# Altium schematic sheet L1.SchDoc
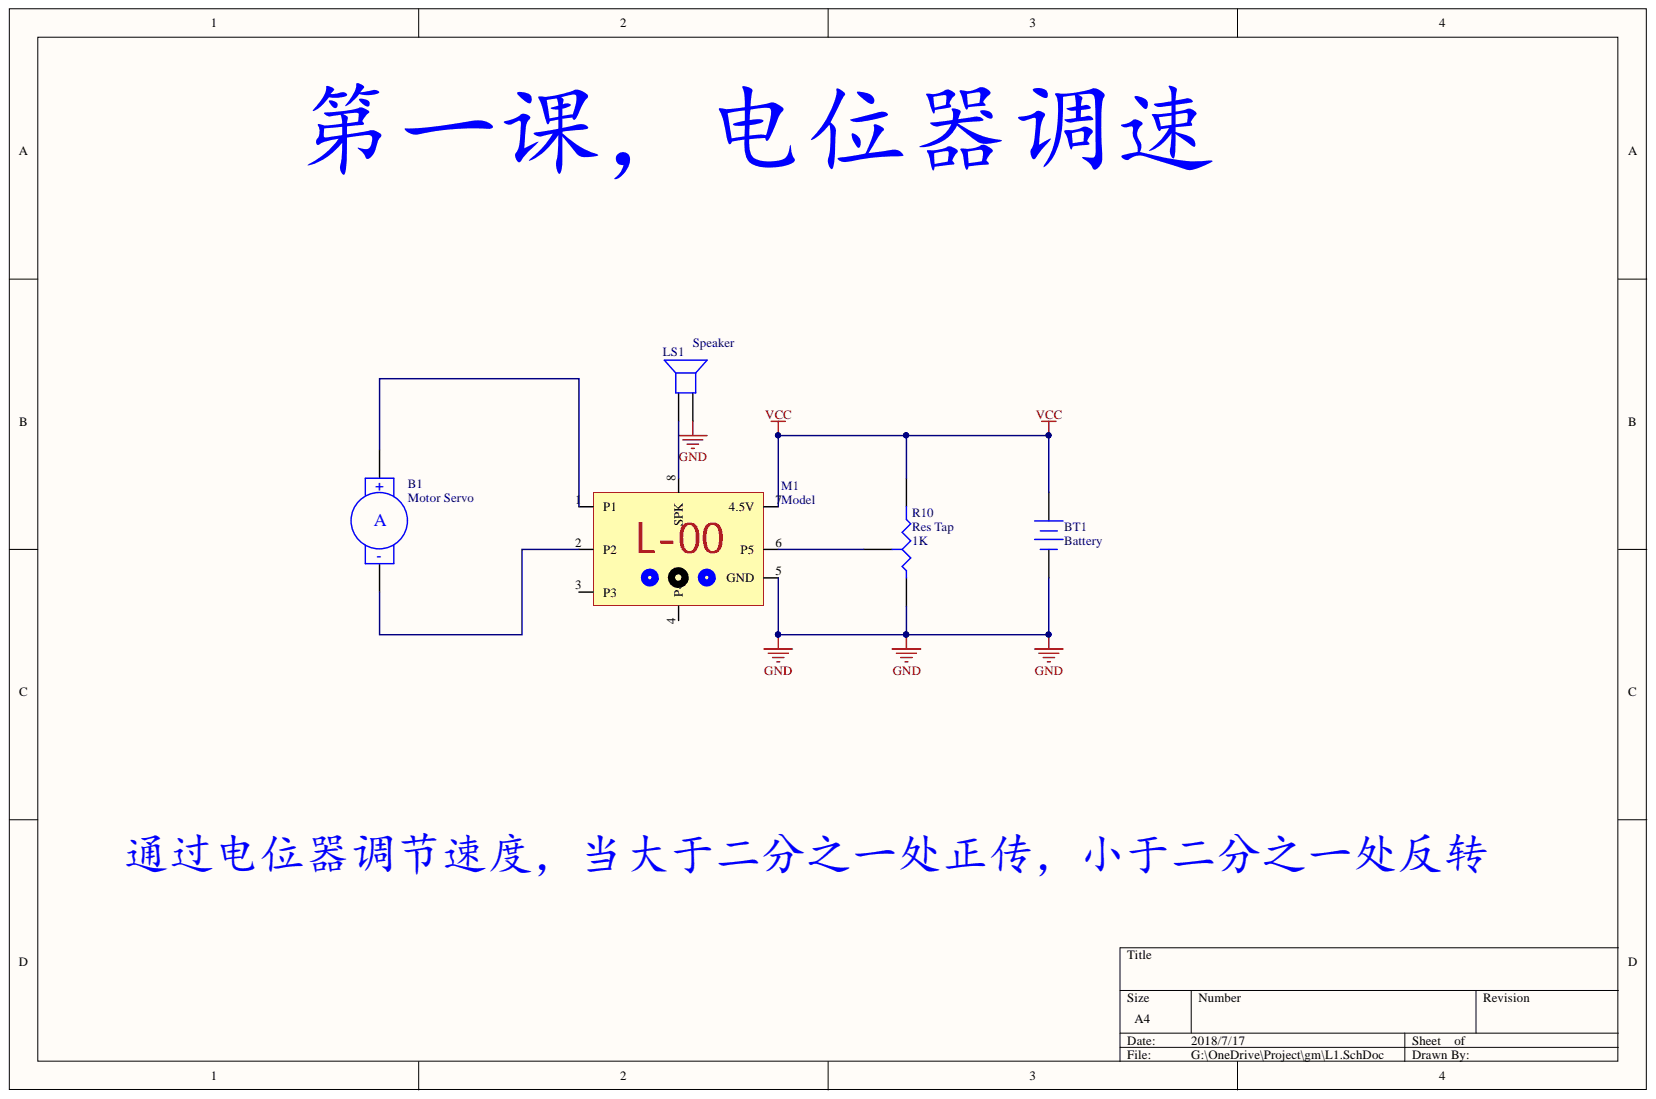
[diagram p0: L1.SchDoc]

## Parts
B1  Motor Servo  [RAD-0.4]
BT1  Battery  [BAT-2]
LS1  Speaker  [PIN2]
M1  Model
R10  Res Tap  value 1K  [VR3]

## Nets
GND: BT1.2 LS1.2 M1.5(GND) R10.1
VCC: BT1.1 M1.7(4.5V) R10.2
NetB1_1: B1.1 M1.1(P1)
NetB1_2: B1.2 M1.2(P2)
NetLS1_1: LS1.1 M1.8(SPK)
NetM1_3: M1.3(P3)
NetM1_4: M1.4(P4)
NetM1_6: M1.6(P5) R10.3
L-00:
第一课，电位器调速:
通过电位器调节速度，当大于二分之一处正传，小于二分之一处反转:

## Library data of the parts
B1 = Motor Servo: Servo Motor; Component Kind: Standard; LatestRevisionDate: 17-Jul-2002; LatestRevisionNote: Re-released for DXP Platform.; Library Name: Miscellaneous Devices.IntLib; PackageDescription: Radial Cap, Thru-Hole; 2 Leads; 0.4 in Pin Spacing; PackageReference: RAD-0.4; Published: 24-Mar-1999; Publisher: Altium Limited
BT1 = Battery: Multicell Battery; Component Kind: Standard; LatestRevisionDate: 17-Jul-2002; LatestRevisionNote: Re-released for DXP Platform.; Library Name: Miscellaneous Devices.IntLib; PackageDescription: Battery; 2 Leads; PackageReference: BAT-2; Published: 24-Mar-1999; Publisher: Altium Limited
LS1 = Speaker: Loudspeaker; Component Kind: Standard; LatestRevisionDate: 17-Jul-2002; LatestRevisionNote: Re-released for DXP Platform.; Library Name: Miscellaneous Devices.IntLib; PackageReference: PIN2; Published: 24-Mar-1999; Publisher: Altium Limited
M1 = M?: 积木模块; Component Kind: Standard; Library Name: L.SchLib
R10 = Res Tap: Tapped Resistor; Set Position: 0.5; Sim Note: Enter the Resistance value and the set point into parameter fields.; Component Kind: Standard; LatestRevisionDate: 17-Jul-2002; LatestRevisionNote: Re-released for DXP Platform.; Library Name: Miscellaneous Devices.IntLib; PackageDescription: Variable Resistor, Thru-Hole; 3 Leads; PackageReference: VR3; Published: 24-Mar-1999; Signal Integrity: Res Tap; Simulation: POT; Publisher: Altium Limited
